# Altium schematic sheet [09] - SD CARD.SchDoc("[09] - SD CARD")
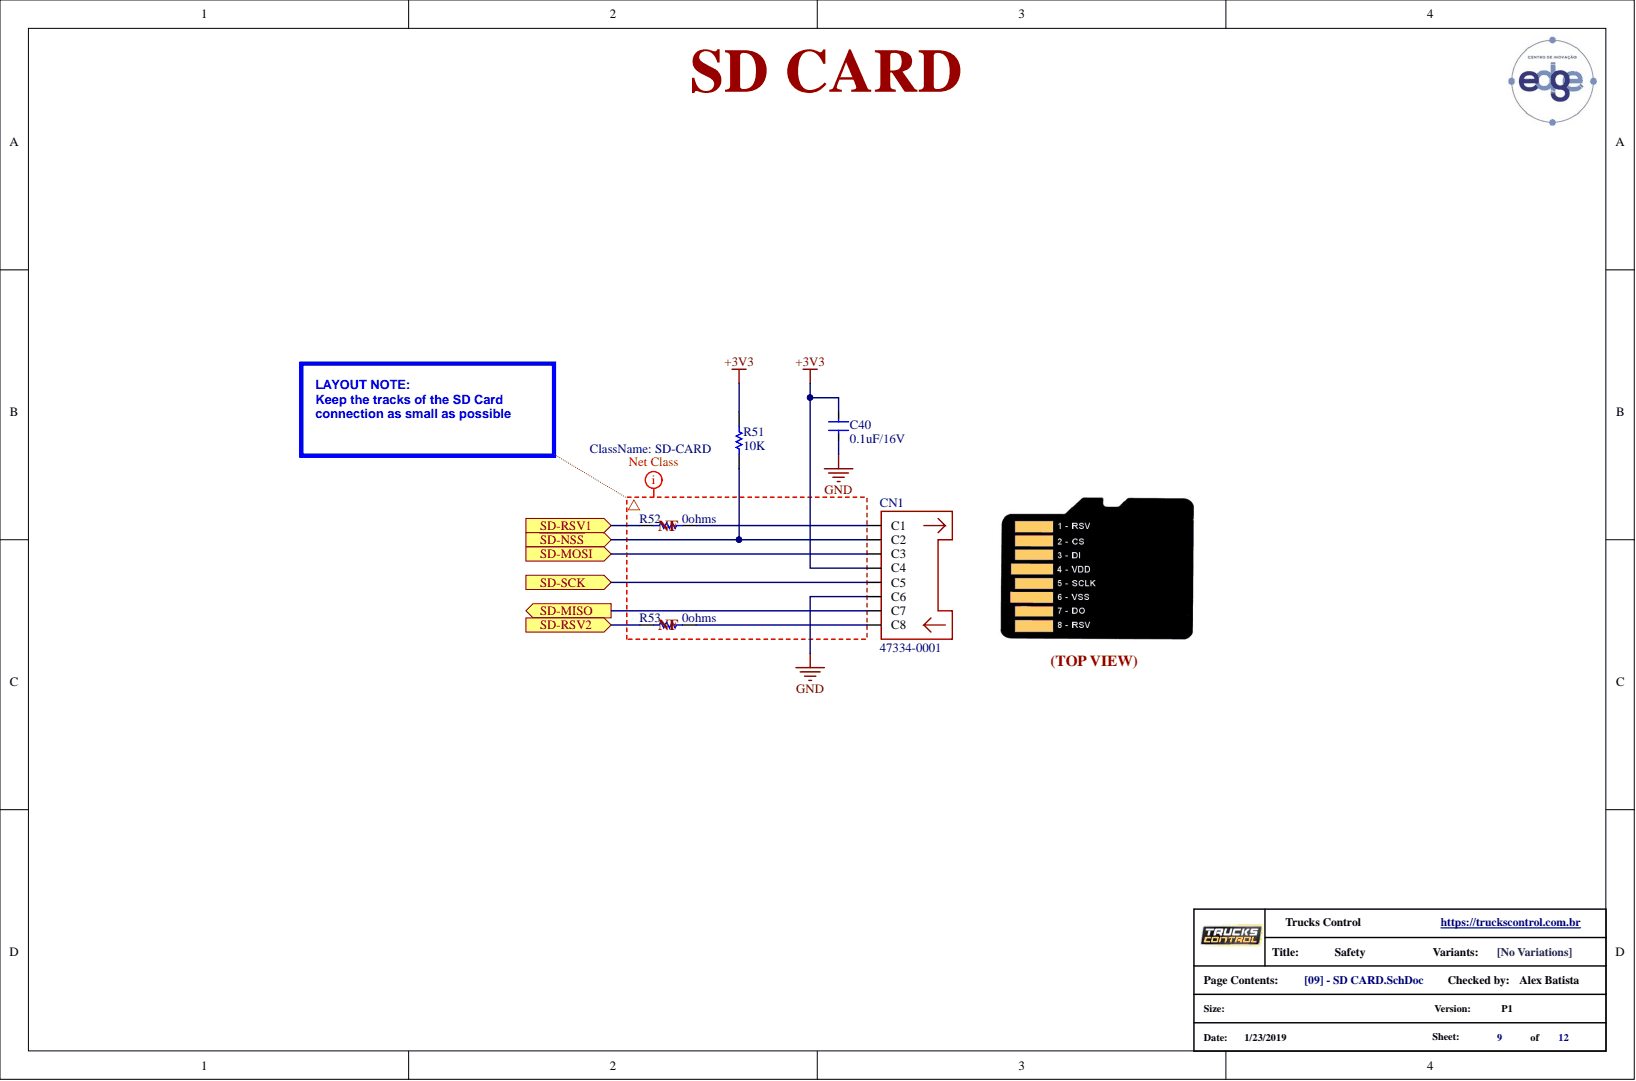
[diagram p0: [09] - SD CARD.SchDoc]

## Parts
C40  0.1uF/16V  [C0402]
CN1  47334-0001  [SDCARD-0473340001]
R51  10K  [R0402]
R52  0ohms  [R0402]
R53  0ohms  [R0402]

## Ports
SD-MISO, SD-MOSI, SD-RSV1, SD-RSV2, SD-SCK, ~{SD-NSS}

## Nets
+3V3: C40.2 CN1.4(C4) R51.2
GND: C40.1 CN1.6(C6)
~{SD-NSS}: CN1.2(C2) R51.1 ; port ~{SD-NSS}
SD-MISO: CN1.7(C7) ; port SD-MISO
SD-MOSI: CN1.3(C3) ; port SD-MOSI
SD-RSV1: R52.1 ; port SD-RSV1
SD-RSV2: R53.1 ; port SD-RSV2
SD-SCK: CN1.5(C5) ; port SD-SCK
NetCN1_1: CN1.1(C1) R52.2
NetCN1_8: CN1.8(C8) R53.2
N00189:

## Library data of the parts
C40 = GCM155R71C104KA55D: 0.1UF 16V X7R 0402 CAP CER; Manufacturer: Murata; Tolerance: 10%; Capacitance: 100nF; Width: 0.02inch; Voltage Rating (DC): 16V; Voltage Rating: 16V; Voltage: 16V; Thickness: 0.022inch; Termination: SMD/SMT; Supplier Part Number 1: 81-GCM155R71C104KA5D; Supplier 1: Mouser; Stock 1: 3750168; REACH SVHC: No SVHC; Ratings: AEC-Q200; Radiation Hardening: No; Pricing 1: 1=0.1, 10=0.061, 50=0.061, 100=0.026, 1000=0.015, 10000=0.01 (USD); Packaging: Tape and Reel; Package Quantity: 1; Mount: Surface Mount; Min Operating Temperature: -55°C; Max Operating Temperature: 125°C; Manufacturer Part Number: GCM155R71C104KA55D; Length: 1mm; Lead Free: Lead Free; Height: 0.5mm; Dielectric: X7R; Depth: 0.5mm; Component Kind: Standard; Case Code (Metric): 1005; Case Code (Imperial): 0402; Case/Package: 0402; Library Name: tc-pcb-safety_V1I1.SchLib
CN1 = 47334-0001: Memory Card Connectors TFR CARD PUSH PUSH T TYPE W/O DETECT PIN; Manufacturer: Molex; Voltage Rating (DC): 100V; Pitch: 1.1mm; Number of Contacts: 8; Gender: Male; Current Rating: 500mA; Connector Type: Socket; Width: 1.88mm; Termination: SMD/SMT; Supplier Part Number 3: 538-47334-0001; Supplier Part Number 2: 47334-0001; Supplier Part Number 1: WM3288TR-ND; Supplier 3: Mouser; Supplier 2: Arrow Electronics Inc.; Supplier 1: Digi-Key; Stock 2: 4800; Stock 1: 12800; RoHS: Yes; REACH SVHC: Unknown; Radiation Hardening: No; Pricing 3: 1 = 3.31000, 10 = 2.92000, 50 = 2.78000, 100 = 2.65000, 1000 = 1.92000, 10000 = 1.92000 (USD); Pricing 2: 2400 = 2.00000, 1 = 3.69800, 10 = 3.53800, 25 = 3.25900, 100 = 2.98100, 250 = 2.64500, 500 = 2.61700, 1000 = 2.14900 (USD); Pricing 1: 800 = 2.05128, 1600 = 1.85276, 2400 = 1.78659, 5600 = 1.72042 (USD); Packaging: Tape and Reel; Orientation: Right Angle; Number of Rows: 1; Number of Circuits: 8; Mount: Surface Mount; Min Operating Temperature: -20°C; Max Voltage Rating (DC): 100V; Max Operating Temperature: 85°C; Manufacturer Part Number: 47334-0001; Length: 14mm; Housing Material: Thermoplastic; Depth: 15.2mm; Contact Plating: Gold; Contact Material: Copper,Copper Alloy,Stainless Steel; ComponentLink4URL: http://www.mouser.com/ds/2/276/0473340001_MEMORY_CARD_SOCKET-146217.pdf; ComponentLink4Description: http://www.mouser.com/ds/2/276/0473340001_MEMORY_CARD_SOCKET-146217.pdf; ComponentLink3URL: http://www.farnell.com/cad/1865996.pdf; ComponentLink3Description: http://www.farnell.com/cad/1865996.pdf; ComponentLink2URL: http://www.molex.com/webdocs/datasheets/pdf/en-us/0473340001_MEMORY_CARD_SOCKET.pdf; ComponentLink2Description: http://www.molex.com/webdocs/datasheets/pdf/en-us/0473340001_MEMORY_CARD_SOCKET.pdf; ComponentLink1URL: http://uk.rs-online.com/webdocs/13c3/0900766b813c3daf.pdf; ComponentLink1Description: http://uk.rs-online.com/webdocs/13c3/0900766b813c3daf.pdf; Component Kind: Standard; Library Name: tc-pcb-safety_V1I1.SchLib
R51 = RC0402JR-7D10KL: 10K OHM 5% 1/16W 0402; Manufacturer: Yageo; Supplier Part Number 1: 603-RC0402JR-7D10KL; Supplier 1: Mouser; Stock 1: 178452; Pricing 1: 1=0.1, 10=0.01, 50=0.01, 100=0.004, 1000=0.003, 10000=0.002 (USD); Manufacturer Part Number: RC0402JR-7D10KL; Component Kind: Standard; Library Name: tc-pcb-safety_V1I1.SchLib
R52 R53 = CRG0402ZR: 0 ohm, 50 V, 0402 [1005 Metric], 63 mW, CRG Series; Manufacturer: TE Connectivity; Voltage Rating (DC): 50V; Tolerance: 0%; Temperature Coefficient: 200ppm/°C; Resistance: 0mR; Power Rating: 63mW; Number of Resistors: 1; Insulation Resistance: 1GR; Width: 0.5mm; Weight: 2.3E-05oz; Voltage Rating: 50V; Termination: Solder; Supplier Part Number 1: 279-CRG0402ZR; Supplier 1: Mouser; Stock 1: 540000; REACH SVHC: Unknown; Radiation Hardening: No; Pricing 1: 10000=0.002 (USD); Packaging: Tape and Reel; Package Quantity: 10000; Number of Terminations: 2; Number of Pins: 2; Mount: Surface Mount; Min Operating Temperature: -55°C; Max Operating Temperature: 125°C; Manufacturer Part Number: CRG0402ZR; Length: 1mm; Height: 0.35mm; ELV: Compliant; Depth: 0.5mm; Composition: Thick Film; Component Kind: Standard; Case Code (Metric): 1005; Case Code (Imperial): 0402; Case/Package: 0402; Library Name: tc-pcb-safety_V1I1.SchLib
